# Altium schematic sheet Connection.SchDoc(Connection)
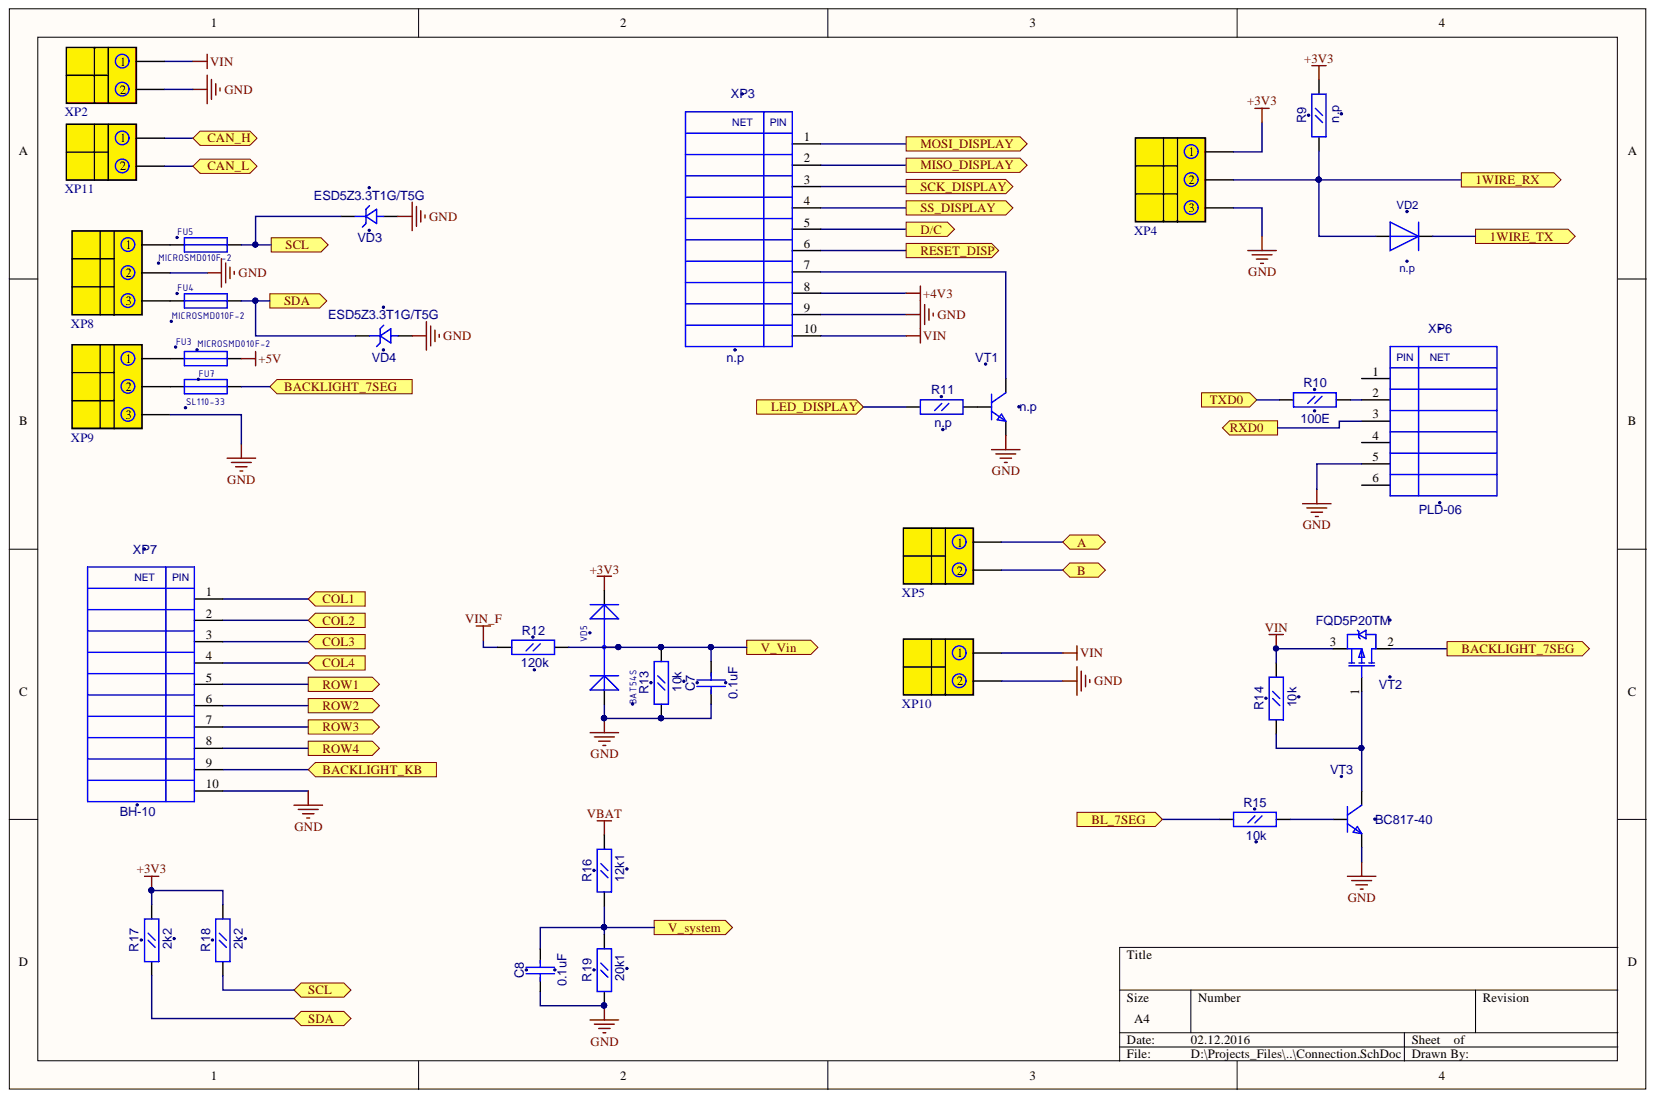
[diagram p0: Connection.SchDoc]

## Parts
C7  0.1uF  [CC0603]
C8  0.1uF  [CC0603]
FU3  MICROSMD010F-2  [RC1210]
FU4  MICROSMD010F-2  [RC1210]
FU5  MICROSMD010F-2  [RC1210]
FU7  SL110-33  [FSMD2920]
R9  n.p  [RC0603]
R10  100E  [RC0603]
R11  n.p  [RC0603]
R12  120k  [RC0603]
R13  10k  [RC0603]
R14  10k  [RC0603]
R15  10k  [RC0603]
R16  12k1  [RC0603]
R17  2k2  [RC0603]
R18  2k2  [RC0603]
R19  20k1  [RC0603]
VD2  n.p  [SOD-80]
VD3  ESD5Z3.3T1G/T5G  [SOD-523]
VD4  ESD5Z3.3T1G/T5G  [SOD-523]
VD5  BAT54S  [SOT-23(GSD)]
VT1  n.p  [SOT-23(123)]
VT2  FQD5P20TM  [D-PAK(TO-252AA)]
VT3  BC817-40  [SOT-23(123)]
XP2  DG330-2PIN  [DG330-2PIN]  (value not drawn)
XP3  n.p  [BH-10]
XP4  n.p  [DG330-3PIN]  (value not drawn)
XP5  DG330-2PIN  [DG330-2PIN]  (value not drawn)
XP6  PLD-06  [PIN6]
XP7  BH-10  [BH-10]
XP8  DG330-3PIN  [DG330-3PIN]  (value not drawn)
XP9  DG330-3PIN  [DG330-3PIN]  (value not drawn)
XP10  DG330-2PIN  [DG330-2PIN]  (value not drawn)
XP11  DG330-2PIN  [DG330-2PIN]  (value not drawn)

## Ports
1WIRE_RX, 1WIRE_TX, A, B, BACKLIGHT_7SEG, BACKLIGHT_KB, BL_7SEG, CAN_H, CAN_L, COL1, COL2, COL3, COL4, D/C, LED_DISPLAY, MISO_DISPLAY, MOSI_DISPLAY, RESET_DISP, ROW1, ROW2, ROW3, ROW4, RXD0, SCK_DISPLAY, SCL, SDA, SS_DISPLAY, TXD0, V_SYSTEM, V_VIN

## Nets
+3V3: R9.2 R17.2 R18.2 VD5.2 XP4.1
+4V3: XP3.8
+5V: FU3.2
GND: C7.1 C8.1 R13.1 R19.1 VD3.1 VD4.1 VD5.1 VT1.2 VT3.2 XP2.2 XP3.9 XP4.3 XP6.5 XP7.10 XP8.2 XP9.3 XP10.2
VBAT: R16.2
VIN: R14.2 VT2.3 XP2.1 XP3.10 XP10.1
VIN_F: R12.1
1WIRE_RX: R9.1 VD2.1 XP4.2 ; port 1WIRE_RX
1WIRE_TX: VD2.2 ; port 1WIRE_TX
A: XP5.1 ; port A
B: XP5.2 ; port B
BACKLIGHT_7SEG: FU7.2 VT2.2 ; port BACKLIGHT_7SEG
BACKLIGHT_KB: XP7.9 ; port BACKLIGHT_KB
BL_7SEG: R15.1 ; port BL_7SEG
CAN_H: XP11.1 ; port CAN_H
CAN_L: XP11.2 ; port CAN_L
COL1: XP7.1 ; port COL1
COL2: XP7.2 ; port COL2
COL3: XP7.3 ; port COL3
COL4: XP7.4 ; port COL4
D/C: XP3.5 ; port D/C
LED_DISPLAY: R11.1 ; port LED_DISPLAY
MISO_DISPLAY: XP3.2 ; port MISO_DISPLAY
MOSI_DISPLAY: XP3.1 ; port MOSI_DISPLAY
RESET_DISP: XP3.6 ; port RESET_DISP
ROW1: XP7.5 ; port ROW1
ROW2: XP7.6 ; port ROW2
ROW3: XP7.7 ; port ROW3
ROW4: XP7.8 ; port ROW4
RXD0: XP6.3 ; port RXD0
SCK_DISPLAY: XP3.3 ; port SCK_DISPLAY
SCL: FU5.2 R18.1 VD3.2 ; port SCL
SDA: FU4.2 R17.1 VD4.2 ; port SDA
SS_DISPLAY: XP3.4 ; port SS_DISPLAY
TXD0: R10.1 ; port TXD0
NetC7_2: C7.2 R12.2 R13.2 VD5.3 ; port V_VIN
NetC8_2: C8.2 R16.1 R19.2 ; port V_SYSTEM
NetFU3_1: FU3.1 XP9.1
NetFU4_1: FU4.1 XP8.3
NetFU5_1: FU5.1 XP8.1
NetFU7_1: FU7.1 XP9.2
NetR10_2: R10.2 XP6.2
NetR11_2: R11.2 VT1.1
NetR14_1: R14.1 VT2.1 VT3.3
NetR15_2: R15.2 VT3.1
NetVT1_3: VT1.3 XP3.7

Not connected: XP6 1 4 6

## Library data of the parts
C7 C8 = CC0603: Component Kind: Standard; Description: CC0603; Value: 0.1uF; Library Name: gprs_modem_2.SchLib
FU3-FU5 = MICROSMD: Component Kind: Standard; Description: MICROSMD; Value: MICROSMD010F-2; Library Name: gprs_modem_2.SchLib
FU7 = FSMD2920: FSMD150-2920; Component Kind: Standard; Library Name: gprs_modem_2.SchLib
R9-R19 = RC0603: Component Kind: Standard; Description: RC0603; Library Name: gprs_modem_2.SchLib
  R9 R11: Value: n.p
  R10: Value: 100E
  R12: Value: 120k
  R13-R15: Value: 10k
  R16: Value: 12k1
  R17 R18: Value: 2k2
  R19: Value: 20k1
VD2 = LL4148: Component Kind: Standard; Description: LL4148; Value: n.p; Library Name: gprs_modem_2.SchLib
VD3 VD4 = ESD5Z: Supressor; Component Kind: Standard; Value: ESD5Z3.3T1G/T5G; Library Name: gprs_modem_2.SchLib
VD5 = BAT54S: 1.0 Ampere General Purpose Rectifier; ComponentField6: Published 8-Jun-2000, Modified 11-July-2000: SIM Model Added.; Component Kind: Standard; Simulation: DIODE; Library Name: gprs_modem_2.SchLib
VT1 = BC846BLT1: NPN 500ma transistor; Component Kind: Standard; Simulation: BC846BLT1; Value: n.p; Library Name: gprs_modem_2.SchLib
VT2 = IRFR9220: HEXFET Power MOSFET; ComponentField7: Published 8-Jun-2000; OriginalName: IRLML5103; Component Kind: Standard; Value: FQD5P20TM; Library Name: gprs_modem_2.SchLib
VT3 = BC846BLT1: NPN 500ma transistor; ComponentField7: Published 29-Sep-2000; Component Kind: Standard; OriginalName: BC846BLT1; Simulation: BC846BLT1; Value: BC817-40; Library Name: gprs_modem_2.SchLib
XP3 = BH-10: Component Kind: Standard; Description: BH-10; Value: n.p; Library Name: gprs_modem_2.SchLib
XP4 = DG330-3PIN: Component Kind: Standard; Value: n.p; Library Name: gprs_modem_2.SchLib
XP6 = PLD-06: PLD06; Component Kind: Standard; Library Name: gprs_modem_2.SchLib
XP2 XP5 XP10 XP11 = DG330-2PIN: Component Kind: Standard; Value: DG330-2PIN; Library Name: gprs_modem_2.SchLib
XP7 = BH-10: Component Kind: Standard; Description: BH-10; Library Name: gprs_modem_2.SchLib
XP8 XP9 = DG330-3PIN: Component Kind: Standard; Value: DG330-3PIN; Library Name: gprs_modem_2.SchLib
